# Altium schematic sheet eRatPlatform_BottomShieldsConnectors.SchDoc
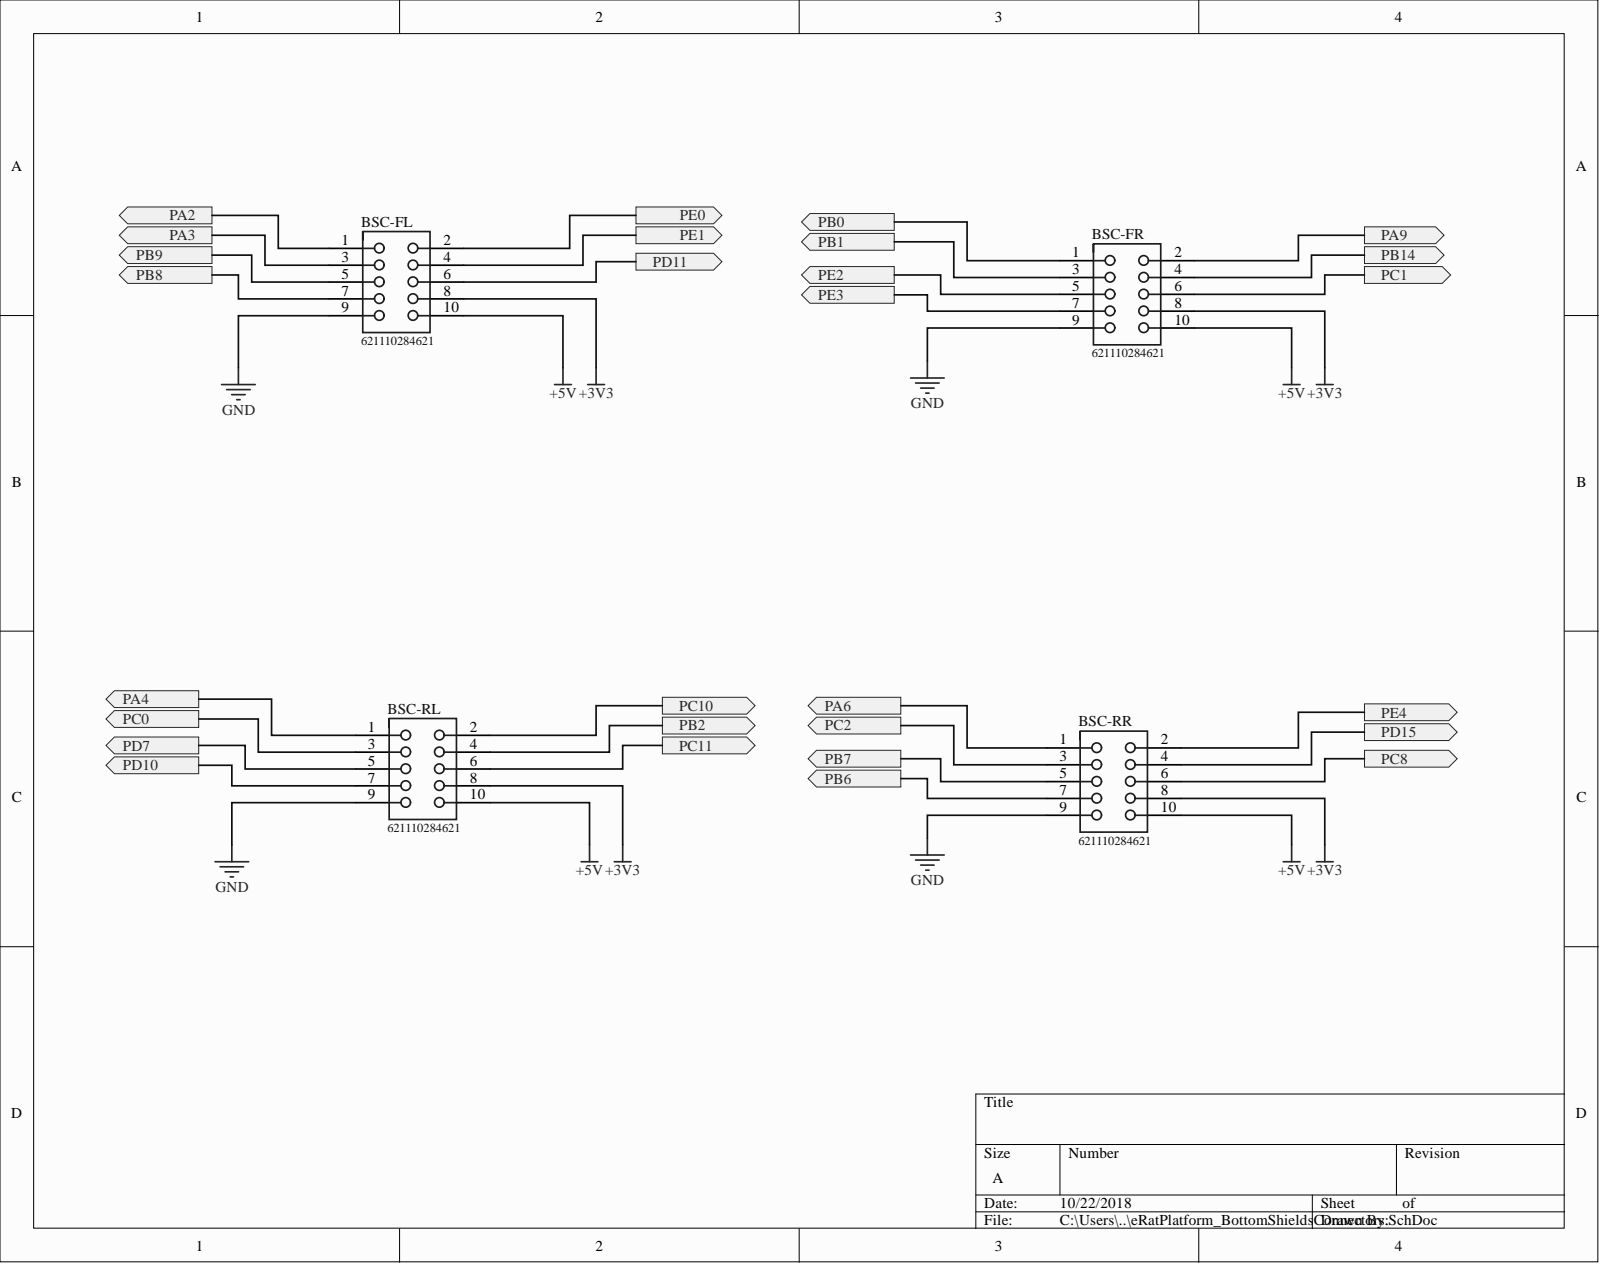
[diagram p0: eRatPlatform_BottomShieldsConnectors.SchDoc]

## Parts
BSC-FL  621110284621  [621110284621]
BSC-FR  621110284621  [621110284621]
BSC-RL  621110284621  [621110284621]
BSC-RR  621110284621  [621110284621]

## Ports
PA2, PA3, PA4, PA6, PA9, PB0, PB1, PB2, PB6, PB7, PB8, PB9, PB14, PC0, PC1, PC2, PC8, PC10, PC11, PD7, PD10, PD11, PD15, PE0, PE1, PE2, PE3, PE4

## Nets
+3V3: BSC-FL.8 BSC-FR.8 BSC-RL.8 BSC-RR.8
+5V: BSC-FL.10 BSC-FR.10 BSC-RL.10 BSC-RR.10
GND: BSC-FL.9 BSC-FR.9 BSC-RL.9 BSC-RR.9
PA2: BSC-FL.1 ; port PA2
PA3: BSC-FL.3 ; port PA3
PA4: BSC-RL.1 ; port PA4
PA6: BSC-RR.1 ; port PA6
PA9: BSC-FR.2 ; port PA9
PB0: BSC-FR.1 ; port PB0
PB1: BSC-FR.3 ; port PB1
PB2: BSC-RL.4 ; port PB2
PB6: BSC-RR.7 ; port PB6
PB7: BSC-RR.5 ; port PB7
PB8: BSC-FL.7 ; port PB8
PB9: BSC-FL.5 ; port PB9
PB14: BSC-FR.4 ; port PB14
PC0: BSC-RL.3 ; port PC0
PC1: BSC-FR.6 ; port PC1
PC2: BSC-RR.3 ; port PC2
PC8: BSC-RR.6 ; port PC8
PC10: BSC-RL.2 ; port PC10
PC11: BSC-RL.6 ; port PC11
PD7: BSC-RL.5 ; port PD7
PD10: BSC-RL.7 ; port PD10
PD11: BSC-FL.6 ; port PD11
PD15: BSC-RR.4 ; port PD15
PE0: BSC-FL.2 ; port PE0
PE1: BSC-FL.4 ; port PE1
PE2: BSC-FR.5 ; port PE2
PE3: BSC-FR.7 ; port PE3
PE4: BSC-RR.2 ; port PE4

## Library data of the parts
BSC-FL BSC-FR BSC-RL BSC-RR = 621110284621: SMT Angled Socket Header WR-PHD, Pitch 2.00 mm, Dual Row, 10 pins; Contact Resistance: 20 mOhm; Gender: Female; Pin Number: 10; Pitch: 2.00 mm; Rated Current: 2 A; Withstanding Voltage: 500 VAC; Working Voltage: 250 VAC; Component Kind: Standard; ComponentLink1Description: Manufacturer; ComponentLink1URL: http://www.we-online.de; ComponentLink2Description: Datasheet; ComponentLink2URL: https://katalog.we-online.de/em/datasheet/621110284621.pdf; Library Name: ALTIUM-WR-PHD_SOCKET_HEADER-rev18b.IntLib; Mounting Technology: SMT; Operating Temperature: -40 to 105 degC; Orientation: Angled; Packaging: Tape and Reel; Part Number: 621110284621; Published Date: August 2018; Row Number: Dual; Publisher: Wurth Elektronik
